# Altium schematic sheet 2-FET Control.SchDoc("2-FET Control")
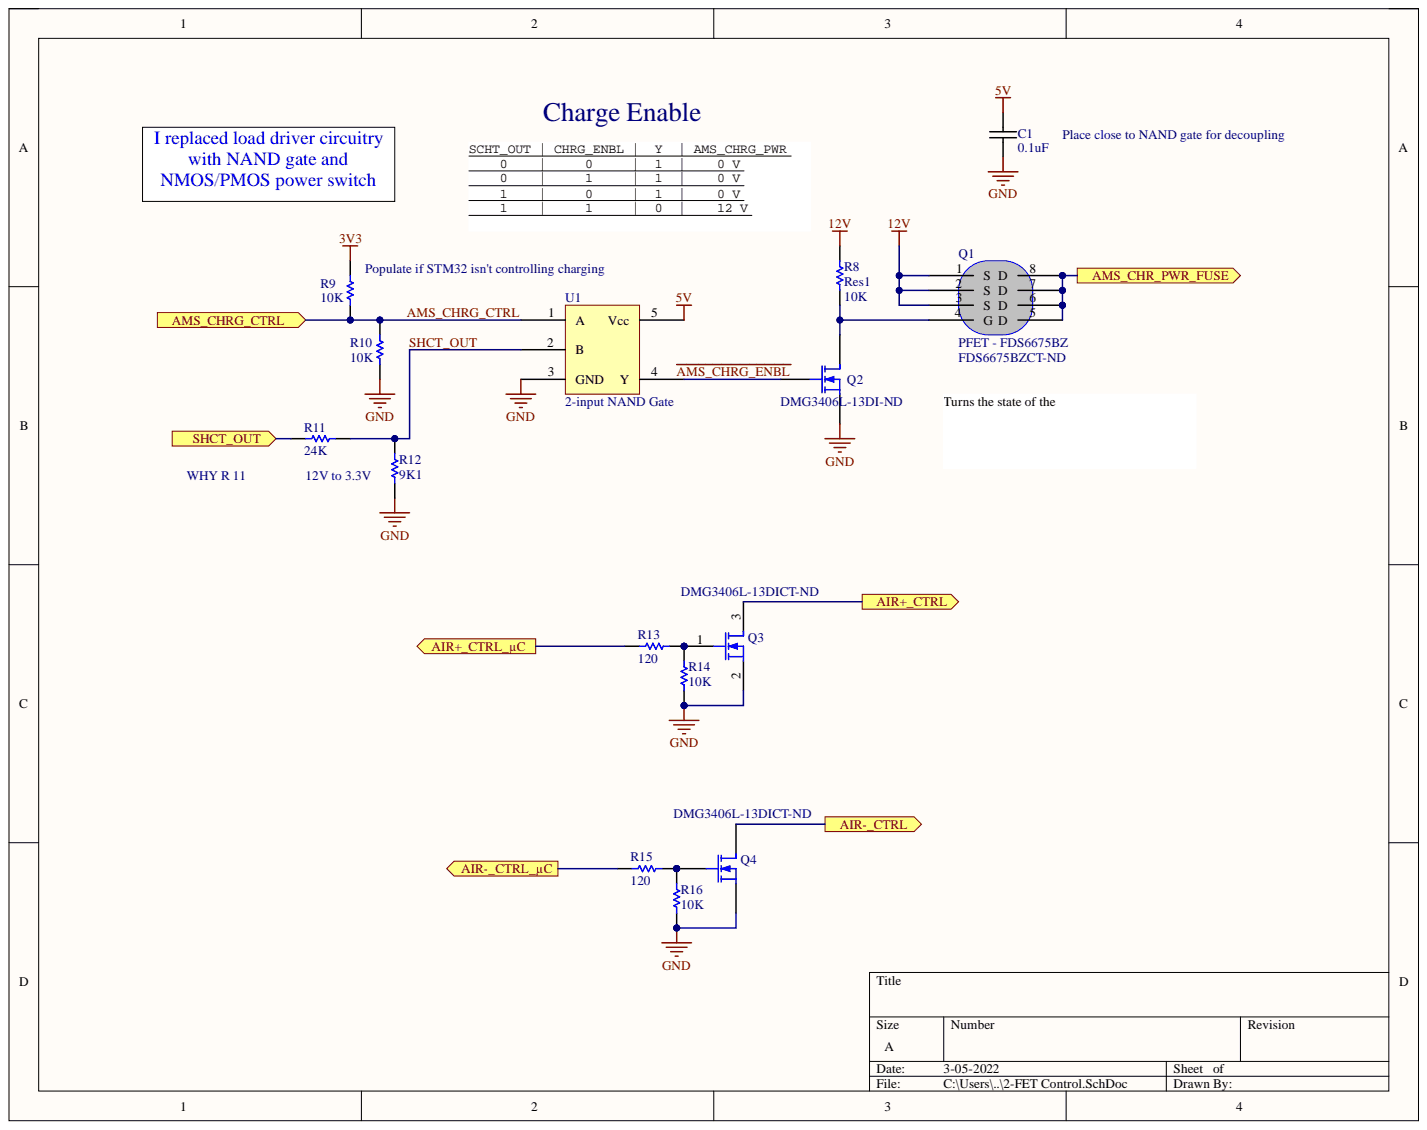
[diagram p0: 2-FET Control.SchDoc]

## Parts
C1  value 0.1uF  [1608[0603]]  (hidden comment: 100nF)
Q1  PFET - FDS6675BZ  Manufacturer Part Number: FDS6675BZ  Supplier Part Number 1: FDS6675BZCT-ND  [SOIC127P600X175-8N]
Q2  Supplier Part Number 1: DMG3406L-13DI-ND  [SOT-23_N]
Q3  Supplier Part Number 1: DMG3406L-13DICT-ND  [SOT-23_N]
Q4  Supplier Part Number 1: DMG3406L-13DICT-ND  [SOT-23_N]
R8  Res1  value 10K  [1608[0603]]
R9  10K  [RESC0603(1608)_L]
R10  10K  [RESC0603(1608)_L]
R11  24K  [RESC0603(1608)_N]
R12  9K1  [RESC0603(1608)_M]
R13  120  [1608[0603]]
R14  10K  [1608[0603]]
R15  120  [1608[0603]]
R16  10K  [1608[0603]]
U1  2-input NAND Gate  Logic Type: NAND Gate  [SOT23-6_M]

## Ports
AIR+_CTRL, AIR+_CTRL_µC, AIR-_CTRL, AIR-_CTRL_µC, AMS_CHR_PWR_FUSE, AMS_CHRG_CTRL, SHCT_OUT

## Nets
3V3: R9.2
5V: C1.1 U1.5(Vcc)
12V: Q1.1(S) Q1.2(S) Q1.3(S) R8.2
GND: C1.2 Q2.2 Q3.2 Q4.2 R10.1 R12.1 R14.1 R16.1 U1.3(GND)
AMS_CHR_PWR_FUSE: Q1.5(D) Q1.6(D) Q1.7(D) Q1.8(D) ; port AMS_CHR_PWR_FUSE
AMS_CHRG_CTRL: R9.1 R10.2 U1.1(A) ; port AMS_CHRG_CTRL
SHCT_OUT: R11.1 R12.2 U1.2(B)
NetQ1_4: Q1.4(G) Q2.3 R8.1
NetQ2_1: Q2.1 U1.4(Y)
NetQ3_1: Q3.1 R13.2 R14.2
NetQ3_3: Q3.3
NetQ4_1: Q4.1 R15.2 R16.2
NetQ4_3: Q4.3
NetR11_2: R11.2 ; port SHCT_OUT
NetR13_1: R13.1
NetR15_1: R15.1
~{AMS_CHRG_ENBL}:

## Library data of the parts
C1 = 0805C-100nF-25V-X7R-MUR: CAP CER 0.1UF 50V X7R 0603; Manufacturer: Kemet; Manufacturer Part Number: C0603C104K5RACAUTO; Capacitance: 0.1µF; Tolerance: ±10%; Applications: Automotive; Category: Capacitors; Component Kind: Standard; ComponentLink1Description: http://capacitoredge.kemet.com/capedge2/DataSheet?pn=C0603C104K5RACAUTO; ComponentLink1URL: http://capacitoredge.kemet.com/capedge2/DataSheet?pn=C0603C104K5RACAUTO; Features: -; Height - Seated (Max): -; Lead Spacing: -; Lead Style: -; Library Name: vh_altium_library.intLib; Mounting Type: Surface Mount, MLCC; Operating Temperature: -55°C ~ 125°C; Package / Case: 0603 (1608 Metric); Packaging: Cut Tape (CT); Pricing 1: 1=0.14, 10=0.095, 25=0.0672, 50=0.0514, 100=0.0435, 250=0.03556, 1000=0.02324 (CAD); Ratings: AEC-Q200; RoHS: RoHS Compliant; Size / Dimension: 0.063" L x 0.031" W (1.60mm x 0.80mm); Stock 1: 157876; Supplier 1: Digi-Key; Supplier Part Number 1: 399-6856-1-ND; Temperature Coefficient: X7R; Thickness (Max): 0.034" (0.87mm); Voltage - Rated: 50V
Q1 = MOSFET: MOSFET P-CH 8-SOIC; Manufacturer: Fairchild/ON Semiconductor; Current - Continuous Drain (Id) @ 25°C: 11A (Ta); Drain to Source Voltage (Vdss): 30V; Drive Voltage (Max Rds On,  Min Rds On): 4.5V, 10V; FET Type: P-Channel; Gate Charge (Qg) (Max) @ Vgs: 62nC @ 10V; Input Capacitance (Ciss) (Max) @ Vds: 2470pF @ 15V; Power Dissipation (Max): 2.5W (Ta); Rds On (Max) @ Id, Vgs: 13 mOhm @ 11A, 10V; Vgs (Max): ±25V; Vgs(th) (Max) @ Id: 3V @ 250µA; Category: Discrete Semiconductor Products; Component Kind: Standard; ComponentLink1Description: https://www.fairchildsemi.com/datasheets/FD/FDS6675BZ.pdf; ComponentLink1URL: https://www.fairchildsemi.com/datasheets/FD/FDS6675BZ.pdf; FET Feature: -; Mounting Type: Surface Mount; Operating Temperature: -55°C ~ 150°C (TJ); Package / Case: 8-SOIC (0.154", 3.90mm Width); Packaging: Cut Tape (CT); Part Status: Active; Pricing 1: 1=1.29, 10=1.156, 100=0.9013, 500=0.74452, 1000=0.58778 (CAD); RoHS: RoHS Compliant; Stock 1: 7940; Supplier 1: Digi-Key; Supplier Device Package: 8-SO; Technology: MOSFET (Metal Oxide); Library Name: Latching_Reset_Library.SchLib
Q2-Q4 = MOSFET-N: N-Channel MOSFET; Manufacturer: Infineon Technologies; Manufacturer Part Number: IPD075N03L G; Current - Continuous Drain (Id) @ 25°C: 50A (Tc); Drain to Source Voltage (Vdss): 30V; FET Feature: Logic Level Gate; FET Type: MOSFET N-Channel, Metal Oxide; Gate Charge (Qg) @ Vgs: 18nC @ 10V; Input Capacitance (Ciss) @ Vds: 1900pF @ 15V; Power - Max: 47W; Rds On (Max) @ Id, Vgs: 7.5 mOhm @ 30A, 10V; Vgs(th) (Max) @ Id: 2.2V @ 250µA; Category: Discrete Semiconductor Products; Component Kind: Standard; ComponentLink1Description: http://www.infineon.com/dgdl/Infineon-IPD075N03LG-DS-v02_01-en.pdf?folderId=5546d4694909da4801490a07012f053b&fileId=db3a30431936bc4b011951d824f83aeb; ComponentLink1URL: http://www.infineon.com/dgdl/Infineon-IPD075N03LG-DS-v02_01-en.pdf?folderId=5546d4694909da4801490a07012f053b&fileId=db3a30431936bc4b011951d824f83aeb; ComponentLink2Description: http://www.semicon.toshiba.co.jp/info/docget.jsp?type=datasheet&lang=en&pid=SSM3K329R; ComponentLink2URL: http://www.semicon.toshiba.co.jp/info/docget.jsp?type=datasheet&lang=en&pid=SSM3K329R; LatestRevisionDate: 17-Jul-2002; LatestRevisionNote: Re-released for DXP Platform.; Library Name: Miscellaneous Devices.IntLib; Mounting Type: Surface Mount; Package / Case: TO-252-3, DPak (2 Leads + Tab), SC-63; PackageDescription: TO, Flat Index; 3 In-Line, Axial Leads; Body Dia. 4.6mm; Lead Dia. 0.5 mm (max); PackageReference: E3; PackageVersion: 1995/1996; Packaging: Cut Tape (CT); Published: 8-Jun-2000; RoHS: RoHS Compliant; Simulation: NMOS; Supplier 1: Digi-Key; Supplier Device Package: PG-TO252-3; Publisher: Altium Limited
R8 = Res1: RES SMD 10K OHM 5% 1/4W 0603; Manufacturer: Rohm Semiconductor; Manufacturer Part Number: ESR03EZPJ103; Power (Watts): 0.25W, 1/4W; Temperature Coefficient: ±200ppm/°C; Category: Resistors; Component Kind: Standard; ComponentLink1Description: http://rohmfs.rohm.com/en/products/databook/datasheet/passive/resistor/chip_resistor/esr.pdf; ComponentLink1URL: http://rohmfs.rohm.com/en/products/databook/datasheet/passive/resistor/chip_resistor/esr.pdf; Composition: Thick Film; Failure Rate: -; Features: Automotive AEC-Q200, Pulse Withstanding; Height - Seated (Max): 0.022" (0.55mm); LatestRevisionDate: 17-Jul-2002; LatestRevisionNote: Re-released for DXP Platform.; Library Name: Miscellaneous Devices.IntLib; Operating Temperature: -55°C ~ 155°C; Package / Case: 0603 (1608 Metric); PackageDescription: Axial Device, Thru-Hole; 2 Leads; 0.3 in Pin Spacing; PackageReference: AXIAL-0.3; Packaging: Cut Tape (CT); Part Status: Active; Pricing 1: 1=0.15, 10=0.119, 25=0.0824, 50=0.0642, 100=0.0458, 250=0.03568, 500=0.02836, 1000=0.02012 (CAD); Published: 8-Jun-2000; Resistance (Ohms): 10k; RoHS: RoHS Compliant; Signal Integrity: Res; Simulation: RESISTOR; Size / Dimension: 0.063" L x 0.031" W (1.60mm x 0.80mm); Stock 1: 0; Supplier 1: Digi-Key; Supplier Device Package: 0603; Supplier Part Number 1: RHM10KDCT-ND; Tolerance: ±5%; Publisher: Altium Limited
R9 R10 = CMP-1591-00001-1: 0603 (1608 Metric) Chip Resistor; Case-EIA: 0603; Case-Metric: 1608; Component Kind: Standard; Library Name: Altium Content Vault; PackageReference: RESC0603(1608); Supplier 1: Digi-Key; Supplier Part Number 1: 311-10KGRCT-ND
R11 = CMP-1012-00107-1: 24K 0.1W 5% 0603 (1608 Metric)  SMD; Case-EIA: 0603; Case-Metric: 1608; Value: 24000.00 Ohm; Component Kind: Standard; Library Name: Altium Content Vault; Power: 0.1 W; Technology: SMT; Tolerance: 5 %
R12 = CMP-1012-00097-1: 9K1 0.1W 5% 0603 (1608 Metric)  SMD; Case-EIA: 0603; Case-Metric: 1608; Value: 9100.00 Ohm; Component Kind: Standard; Library Name: Altium Content Vault; Power: 0.1 W; Technology: SMT; Tolerance: 5 %
R13 R15 = Res1: Resistor; Manufacturer: Panasonic Electronic Components; Manufacturer Part Number: ERJ-3EKF60R4V; Power (Watts): 0.1W, 1/10W; Resistance (Ohms): 60.4; Temperature Coefficient: ±100ppm/°C; Tolerance: ±1%; Category: Resistors; Component Kind: Standard; ComponentLink1Description: http://industrial.panasonic.com/www-cgi/jvcr13pz.cgi?E+PZ+3+AOA0002+ERJ3EKF60R4V+7+WW; ComponentLink1URL: http://industrial.panasonic.com/www-cgi/jvcr13pz.cgi?E+PZ+3+AOA0002+ERJ3EKF60R4V+7+WW; Composition: Thick Film; Features: Automotive AEC-Q200; Height: 0.022" (0.55mm); LatestRevisionDate: 17-Jul-2002; LatestRevisionNote: Re-released for DXP Platform.; Library Name: Miscellaneous Devices.IntLib; Number of Terminations: 2; Operating Temperature: -55°C ~ 155°C; Package / Case: 0603 (1608 Metric); PackageDescription: Axial Device, Thru-Hole; 2 Leads; 0.3 in Pin Spacing; PackageReference: AXIAL-0.3; Packaging: Cut Tape (CT); Pricing 1: 1=0.15, 50=0.0176, 100=0.0129, 250=0.00992, 500=0.0079, 1000=0.00583 (CAD); Published: 8-Jun-2000; RoHS: RoHS Compliant; Signal Integrity: Res; Simulation: RESISTOR; Size / Dimension: 0.063" L x 0.031" W (1.60mm x 0.80mm); Stock 1: 113077; Supplier 1: Digi-Key; Supplier Device Package: 0603; Supplier Part Number 1: P60.4HCT-ND; Value: 120; Publisher: Altium Limited
R14 R16 = Res1: Resistor; Manufacturer: Rohm Semiconductor; Manufacturer Part Number: ESR03EZPJ103; Power (Watts): 0.25W, 1/4W; Temperature Coefficient: ±200ppm/°C; Tolerance: ±5%; Category: Resistors; Component Kind: Standard; ComponentLink1Description: http://rohmfs.rohm.com/en/products/databook/datasheet/passive/resistor/chip_resistor/esr.pdf; ComponentLink1URL: http://rohmfs.rohm.com/en/products/databook/datasheet/passive/resistor/chip_resistor/esr.pdf; Composition: Thick Film; Features: Automotive AEC-Q200, Pulse Withstanding; Height: 0.022" (0.55mm); LatestRevisionDate: 17-Jul-2002; LatestRevisionNote: Re-released for DXP Platform.; Library Name: Miscellaneous Devices.IntLib; Number of Terminations: 2; Operating Temperature: -55°C ~ 155°C; Package / Case: 0603 (1608 Metric); PackageDescription: Axial Device, Thru-Hole; 2 Leads; 0.3 in Pin Spacing; PackageReference: AXIAL-0.3; Packaging: Cut Tape (CT); Pricing 1: 1=0.15, 10=0.121, 25=0.0836, 100=0.0466, 250=0.03628, 500=0.02884, 1000=0.02046 (CAD); Published: 8-Jun-2000; Resistance (Ohms): 10k; RoHS: RoHS Compliant; Signal Integrity: Res; Simulation: RESISTOR; Size / Dimension: 0.063" L x 0.031" W (1.60mm x 0.80mm); Stock 1: 8360; Supplier 1: Digi-Key; Supplier Device Package: 0603 (1608 Metric); Supplier Part Number 1: RHM10KDCT-ND; Value: 10K; Publisher: Altium Limited
U1 = NC7SZ08: IC GATE NAND 1CH 2-INP SC-70-5; Manufacturer: Texas Instruments; Manufacturer Part Number: SN74AHC1G00QDCKRQ1; Current - Output High, Low: 8mA, 8mA; Current - Quiescent (Max): 1µA; Logic Level - High: 1.5 V ~ 3.85 V; Logic Level - Low: 0.5 V ~ 1.65 V; Max Propagation Delay @ V, Max CL: 7.5ns @ 5V, 50pF; Number of Circuits: 1; Number of Inputs: 2; Voltage - Supply: 2 V ~ 5.5 V; Category: Integrated Circuits (ICs); Component Kind: Standard; ComponentLink1Description: http://www.ti.com/lit/ds/symlink/sn74ahc1g00-q1.pdf; ComponentLink1URL: http://www.ti.com/lit/ds/symlink/sn74ahc1g00-q1.pdf; Features: Schmitt Trigger; Library Name: FE Schem Lib.SchLib; Mounting Type: Surface Mount; Operating Temperature: -40°C ~ 125°C; Package / Case: 5-TSSOP, SC-70-5, SOT-353; Packaging: Cut Tape (CT); Pricing 1: 1=0.69, 10=0.524, 100=0.3262, 500=0.22294, 1000=0.17137 (CAD); RoHS: RoHS Compliant; Stock 1: 7211; Supplier 1: Digi-Key; Supplier Device Package: SC-70-5; Supplier Part Number 1: 296-23670-1-ND
